# Altium schematic sheet Relay y salida analógica.SchDoc("Relay y salida analógica")
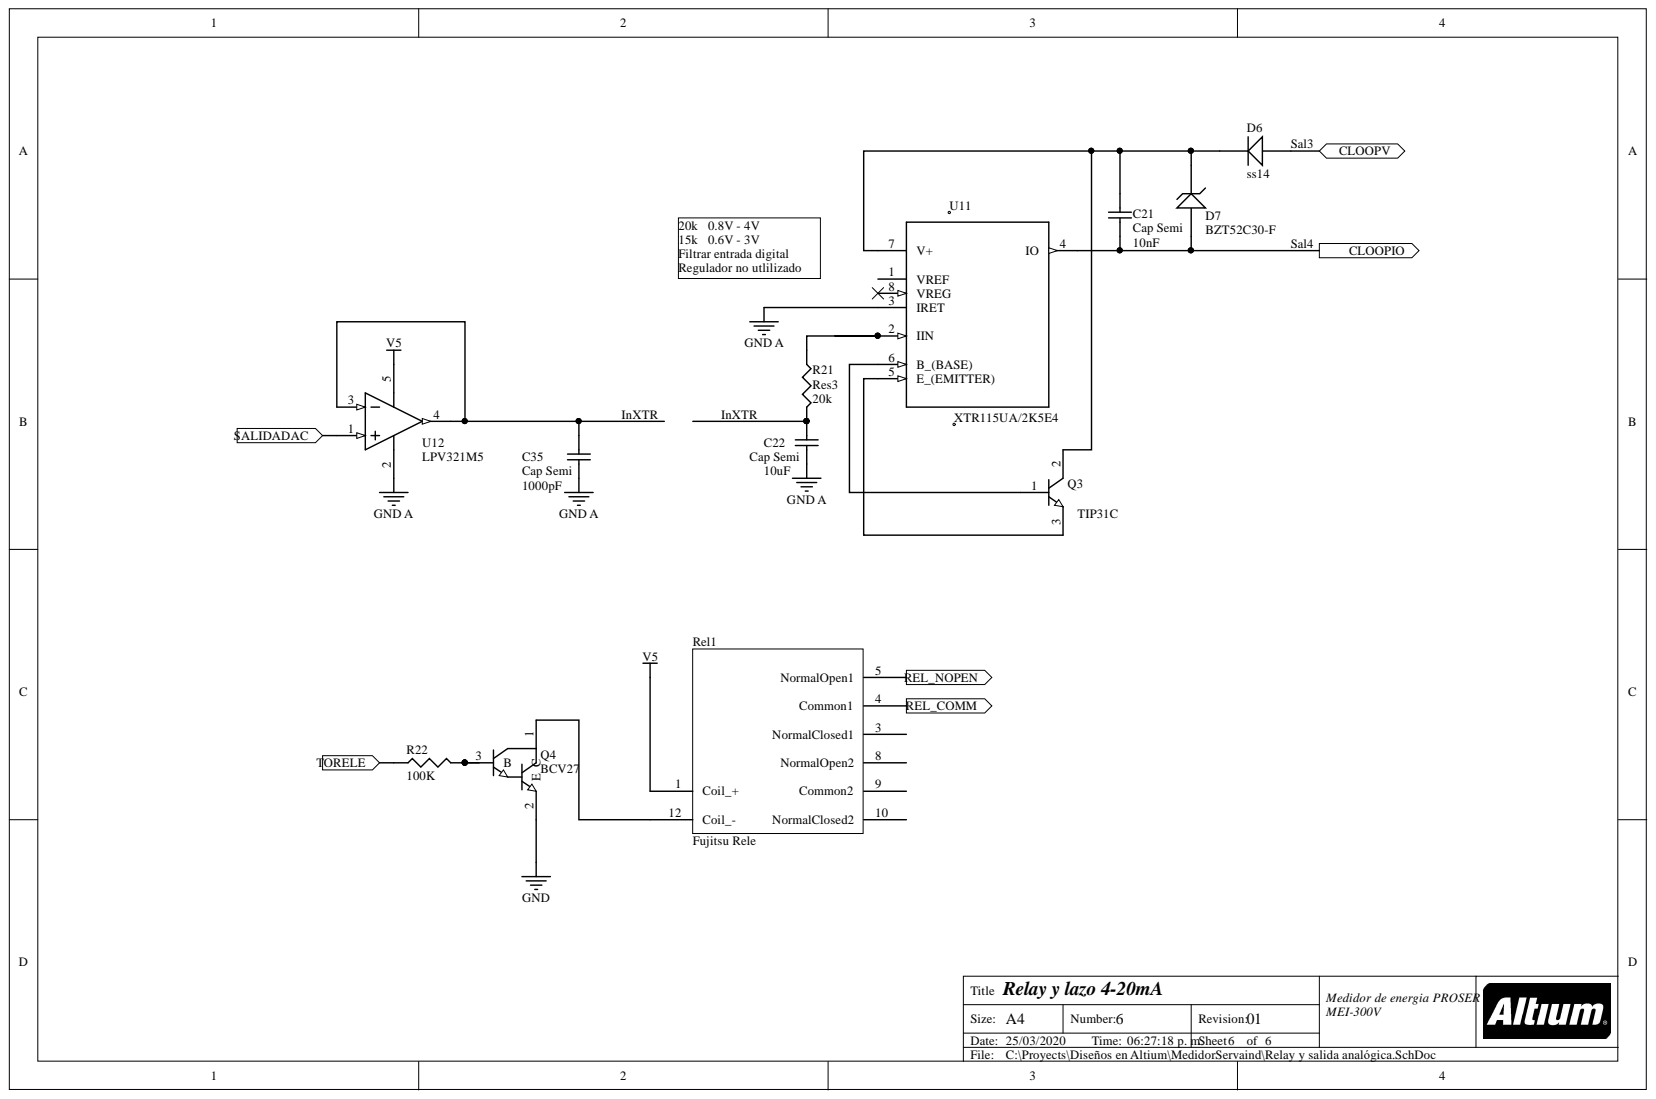
[diagram p0: Relay y salida analógica.SchDoc]

## Parts
C21  Cap Semi  value 10nF  [Custom0805]
C22  Cap Semi  value 10uF  [Custom0805]
C35  Cap Semi  value 1000pF  [Custom0805]
D6  ss14  [DO214]
D7  BZT52C30-F  [1N4148W]
Q3  TIP31C  [TO-220AB]
Q4  BCV27  [SOT23_L]
R21  Res3  value 20k  [Custom0805]
R22  value 100K  [Custom0805]  (hidden comment: Rb)
Rel1  Fujitsu Rele  [Rele_Fujtsu]
U11  XTR115UA/2K5E4  [*SOIC127P600X175-8N]
U12  LPV321M5  [MF05A_N]

## Ports
CLOOPIO, CLOOPV, REL_COMM, REL_NOPEN, SALIDADAC, TORELE

## Nets
GND: Q4.2
GND A: C22.1 C35.1 U11.3(IRET) U12.2
V5: Rel1.1(Coil_+) U12.5
INXTR: C22.2 C35.2 R21.2 U12.3 U12.4
SAL3: D6.1 ; port CLOOPV
SAL4: C21.1 D7.1 U11.4(IO) ; port CLOOPIO
NetC21_2: C21.2 D6.2 D7.2 Q3.2 U11.7(V+)
NetQ3_1: Q3.1 U11.6(B_(BASE))
NetQ3_3: Q3.3 U11.5(E_(EMITTER))
NetQ4_1: Q4.1 Rel1.12(Coil_-)
NetQ4_3: Q4.3 R22.2
NetR21_1: R21.1 U11.2(IIN)
NetR22_1: R22.1 ; port TORELE
NetRel1_4: Rel1.4(Common1) ; port REL_COMM
NetRel1_5: Rel1.5(NormalOpen1) ; port REL_NOPEN
NetU12_1: U12.1 ; port SALIDADAC

Not connected: Rel1 3(NormalClosed1) 8(NormalOpen2) 9(Common2) 10(NormalClosed2); U11 1(VREF) 8(VREG)

## Library data of the parts
C21 C22 C35 = Cap Semi: Capacitor (Semiconductor SIM Model); Component Kind: Standard; LatestRevisionDate: 29-May-2009; LatestRevisionNote: IPC-7351 Footprint Added.; Library Name: Miscellaneous Devices.IntLib; PackageDescription: Chip Capacitor; PackageReference: C1206; Published: 8-Jun-2000; Signal Integrity: Cap Semi; Simulation: CAP; Publisher: Altium Limited
D6 = Diode: Default Diode; Code_JEDEC: DO-214-AB; Component Kind: Standard; LatestRevisionDate: 17-Jul-2002; LatestRevisionNote: Re-released for DXP Platform.; Library Name: Miscellaneous Devices.IntLib; PackageDescription: DO-214-AB/SMC; 2 C-Bend Leads; Body 7.9 x 5.9 mm, inc. leads (LxW); PackageReference: SMC; PackageVersion: Sep-1996; Published: 8-Jun-2000; Signal Integrity: Diode; Simulation: DIODE; Publisher: Altium Limited
D7 = D Zener: Zener Diode SMD; Component Kind: Standard; LatestRevisionDate: 17-Jul-2002; LatestRevisionNote: Re-released for DXP Platform.; Library Name: Miscellaneous Devices.IntLib; PackageDescription: Diode, Thru-Hole; 2 Leads; 0.7 in Pin Spacing; PackageReference: DIODE-0.7; Published: 8-Jun-2000; Signal Integrity: D Zener; Simulation: ZENER; Publisher: Altium Limited
Q3 = NPN: NPN Bipolar Transistor; Code_JEDEC: TO-226-AA; Component Kind: Standard; LatestRevisionDate: 17-Jul-2002; LatestRevisionNote: Re-released for DXP Platform.; Library Name: Miscellaneous Devices.IntLib; PackageDescription: TO, Flat Index; 3 In-Line, Axial Leads; Body Dia. 4.8mm; Leads 0.55 x 0.51 mm (max); PackageReference: TO-226-AA; Published: 8-Jun-2000; Publisher: Altium Limited
Q4 = NPN1: NPN Darlington Bipolar Transistor; Code_JEDEC: TO-92; Component Kind: Standard; DatasheetVersion: 1989; LatestRevisionDate: 17-Jul-2002; LatestRevisionNote: Re-released for DXP Platform.; Library Name: Miscellaneous Devices.IntLib; PackageDescription: TO, Flat Index; 3 In-Line, Axial Leads; Body Dia. 4.6mm; Leads 0.41 x 0.39 mm (max); PackageReference: TO-92; PackageVersion: 1989; Published: 8-Jun-2000; Signal Integrity: NPN; Simulation: NPN1; Publisher: Altium Limited
R21 R22 = Res3: Resistor; Component Kind: Standard; LatestRevisionDate: 29-May-2009; LatestRevisionNote: IPC-7351 Footprint Added.; Library Name: Miscellaneous Devices.IntLib; PackageDescription: Chip Resistor; PackageReference: J1-0603; Published: 8-Jun-2000; Signal Integrity: Res; Simulation: RESISTOR; Publisher: Altium Limited
Rel1 = Rele_2: FBR12ND-05; Component Kind: Standard; Library Name: therele.SchLib
U11 = XTR115UA/2K5E4: Current Loop Transmitter 8-Pin SOIC T/R; MF: Texas Instruments; Availability: Unavailable; Component Kind: Standard; Library Name: XTR115UA_2K5E4.IntLib; MP: XTR115UA/2K5E4; Package: None; Price: None; SnapEDA_Link: https://www.snapeda.com/parts/XTR115UA/2K5E4/Texas%20Instruments/view-part/?ref=snap
U12 = LPV321M5: General Purpose, Low Voltage, Low Power, Rail-to-Rail Output Operational Amplifiers, 5-pin SOT-23; Manufacturer: National Semiconductor; PackageTopMarking: A27A*XTT; PowerWise: Y; Component Kind: Standard; ComponentLink1Description: LPV321 Datasheet; ComponentLink1URL: http://www.national.com/ds/LP/LPV321.pdf; ComponentLink2Description: LPV321 Web Page; ComponentLink2URL: http://www.national.com/pf/LP/LPV321.html; ComponentLink3Description: MF05A Package Page; ComponentLink3URL: http://www.national.com/packaging/folders/mf05a.html; CompType: Amplifiers; DatasheetVersion: Oct-2006; LatestRevisionDate: 13-Jan-2009; LatestRevisionNote: Sim Model Added.; Library Name: NSC Amplifier.IntLib; PackageDescription: Molded SOT-23, .115 x .063 x .040 in Body, 5 Lead, .0375 in Pitch; PackageReference: MF05A; PackageVersion: revD, Aug-2008; PartNumber: LPV321M5; PinCount: 5; Published: 4-Dec-2008; RoHS: N; Simulation: LPV321; Publisher: Altium Limited
